# Altium schematic sheet 10 Digital IO_5V.SchDoc
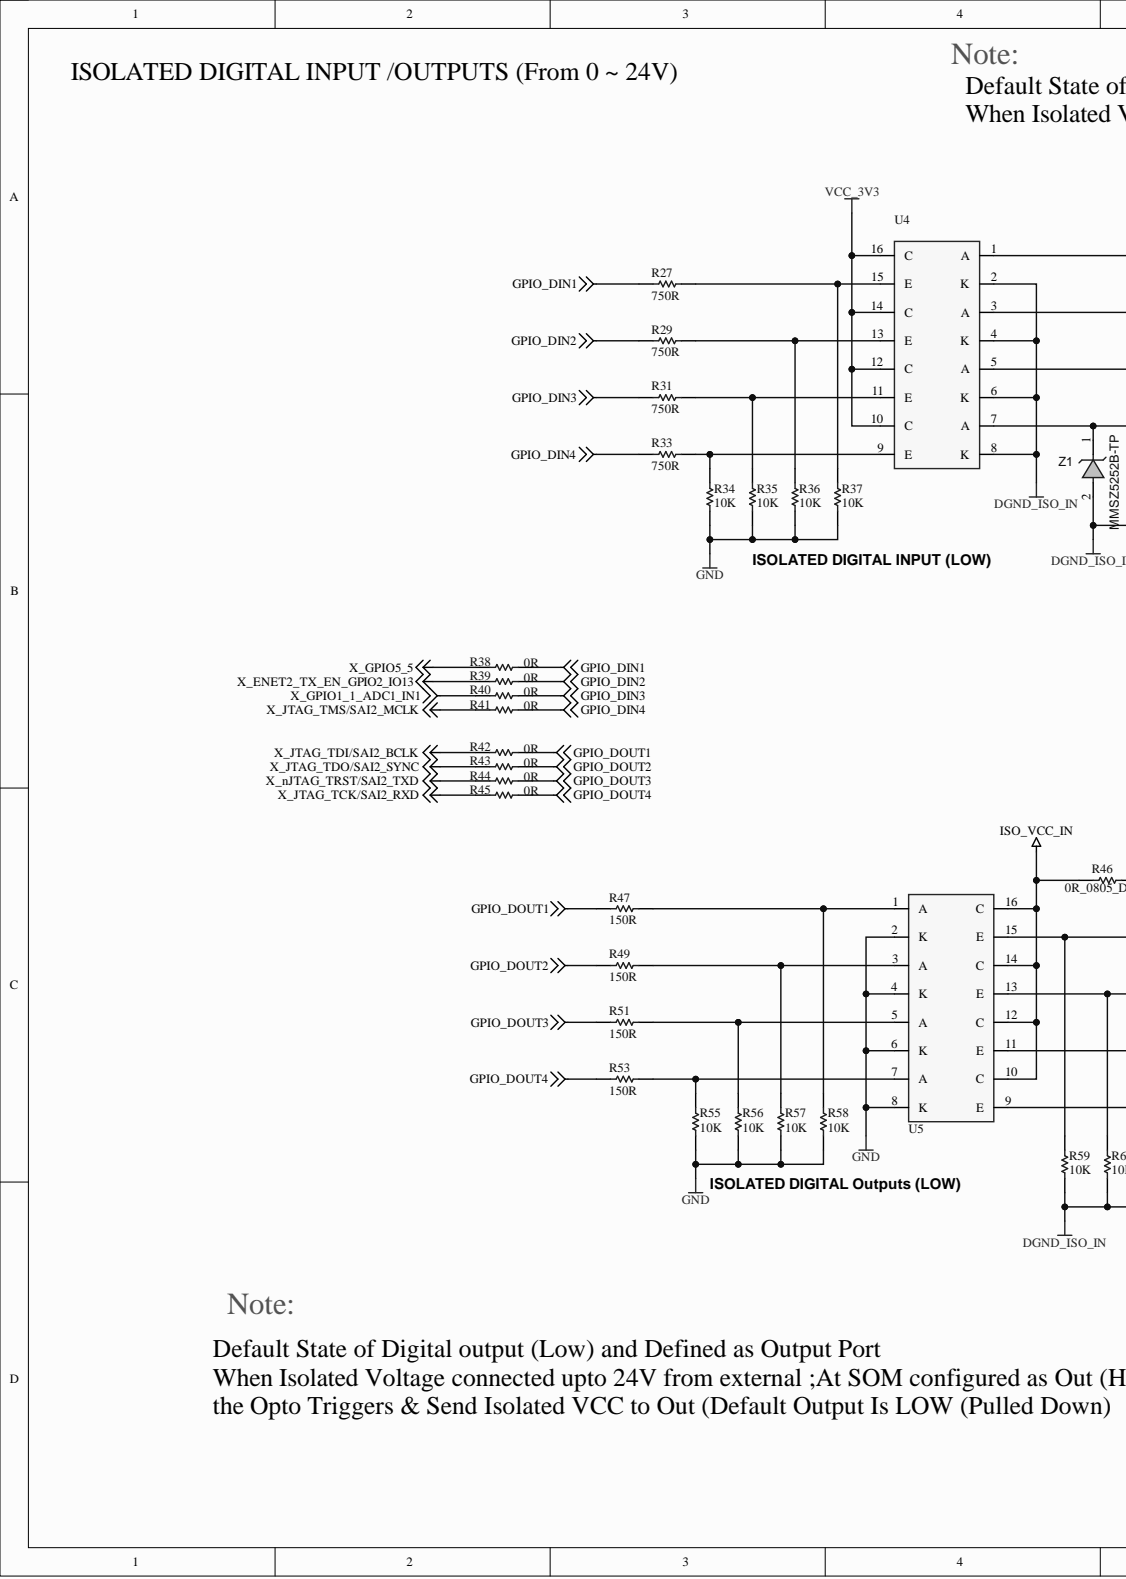
[diagram p0: 10 Digital IO_5V.SchDoc, left]
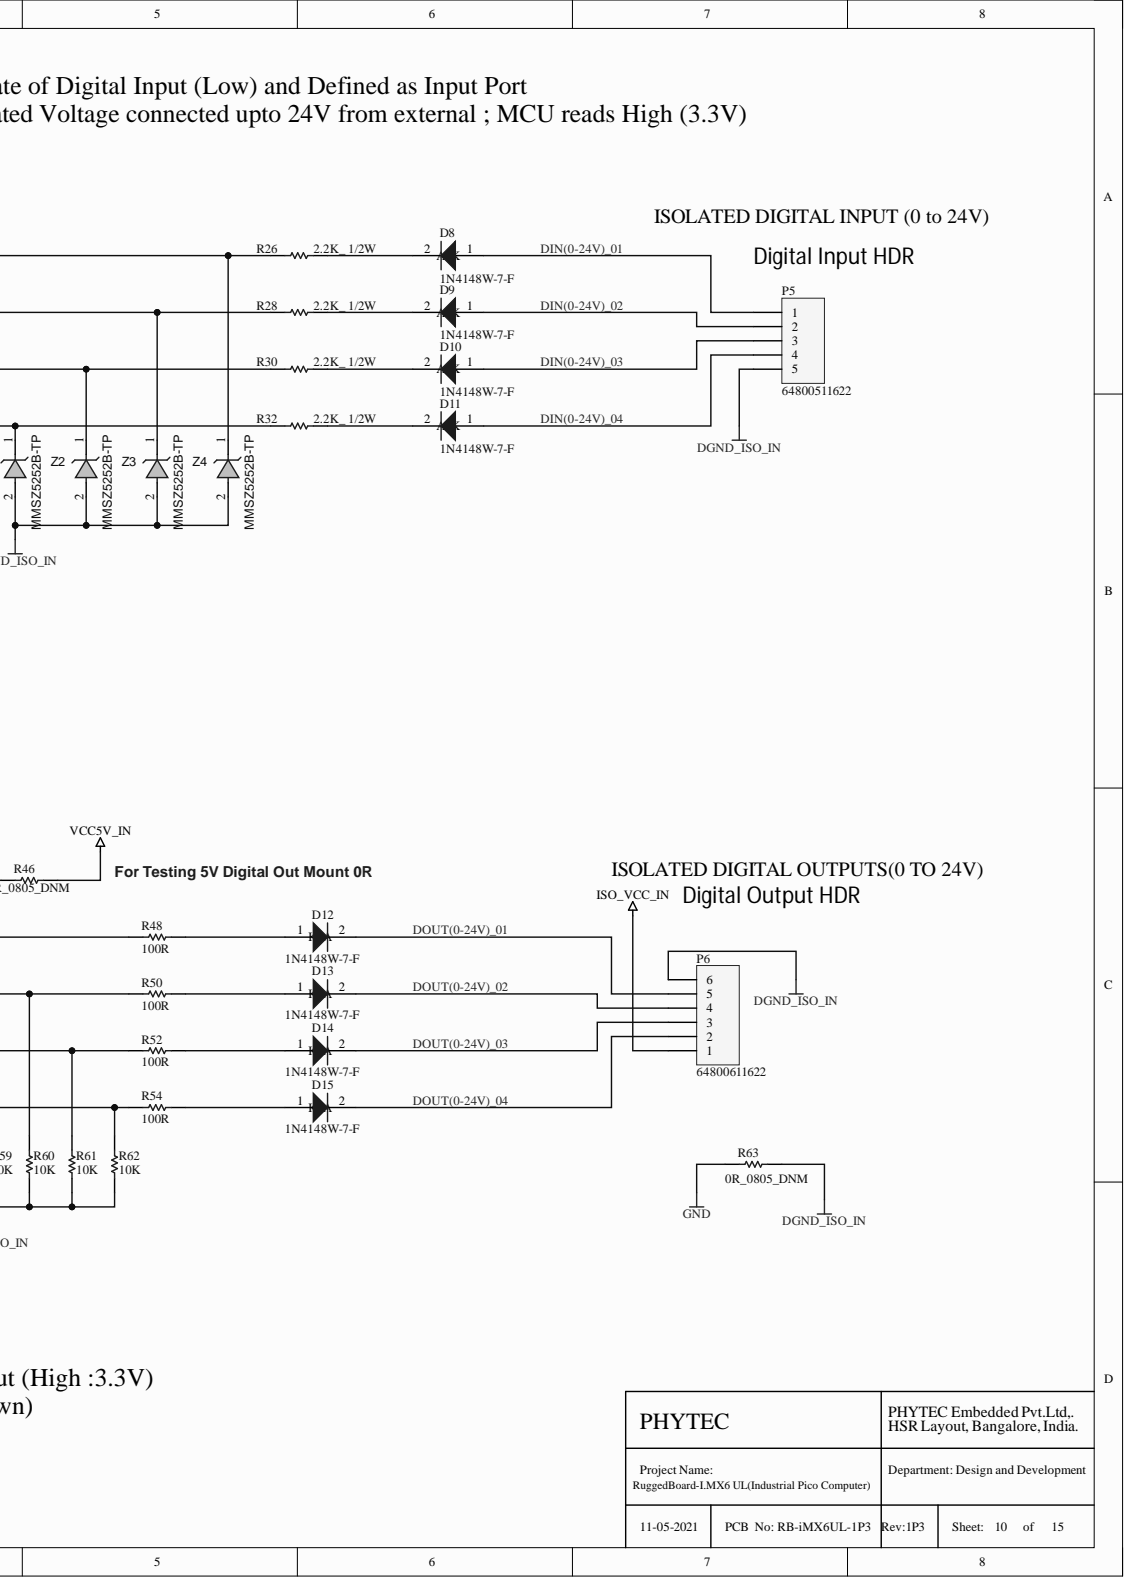
[diagram p1: 10 Digital IO_5V.SchDoc, right]

## Parts
D8  1N4148W-7-F  [SOD-123_1A_2K]
D9  1N4148W-7-F  [SOD-123_1A_2K]
D10  1N4148W-7-F  [SOD-123_1A_2K]
D11  1N4148W-7-F  [SOD-123_1A_2K]
D12  1N4148W-7-F  [SOD-123_1A_2K]
D13  1N4148W-7-F  [SOD-123_1A_2K]
D14  1N4148W-7-F  [SOD-123_1A_2K]
D15  1N4148W-7-F  [SOD-123_1A_2K]
P5  64800511622  [HEADER_15P_1X5N]
P6  64800611622  [HEADER_15P_1X6N]
R26  2.2K_ 1/2W  [R0805]
R27  750R  [R0402]
R28  2.2K_ 1/2W  [R0805]
R29  750R  [R0402]
R30  2.2K_ 1/2W  [R0805]
R31  750R  [R0402]
R32  2.2K_ 1/2W  [R0805]
R33  750R  [R0402]
R34  10K  [R0402]
R35  10K  [R0402]
R36  10K  [R0402]
R37  10K  [R0402]
R38  0R  [R0402]
R39  0R  [R0402]
R40  0R  [R0402]
R41  0R  [R0402]
R42  0R  [R0402]
R43  0R  [R0402]
R44  0R  [R0402]
R45  0R  [R0402]
R46  0R_0805_DNM  [R0805]
R47  150R  [R0402]
R48  100R  [R0402]
R49  150R  [R0402]
R50  100R  [R0402]
R51  150R  [R0402]
R52  100R  [R0402]
R53  150R  [R0402]
R54  100R  [R0402]
R55  10K  [R0402]
R56  10K  [R0402]
R57  10K  [R0402]
R58  10K  [R0402]
R59  10K  [R0402]
R60  10K  [R0402]
R61  10K  [R0402]
R62  10K  [R0402]
R63  0R_0805_DNM  [R0805]
U4  TLP293-4(MBGBTPE  [SOIC127P405X179-16N]  (value not drawn)
U5  TLP293-4(MBGBTPE  [SOIC127P405X179-16N]  (value not drawn)
Z1  MMSZ5252B-TP  [SOD-123]
Z2  MMSZ5252B-TP  [SOD-123]
Z3  MMSZ5252B-TP  [SOD-123]
Z4  MMSZ5252B-TP  [SOD-123]

## Nets
DGND_ISO_IN: P5.5 P6.6 R59.1 R60.1 R61.1 R62.1 R63.1 U4.2(K) U4.4(K) U4.6(K) U4.8(K) Z1.2 Z2.2 Z3.2 Z4.2
GND: R34.1 R35.1 R36.1 R37.1 R55.1 R56.1 R57.1 R58.1 R63.2 U5.2(K) U5.4(K) U5.6(K) U5.8(K)
GPIO_DIN1: R27.2 R38.1
GPIO_DIN2: R29.2 R39.1
GPIO_DIN3: R31.2 R40.1
GPIO_DIN4: R33.2 R41.1
GPIO_DOUT1: R42.1 R47.2
GPIO_DOUT2: R43.1 R49.2
GPIO_DOUT3: R44.1 R51.2
GPIO_DOUT4: R45.1 R53.2
ISO_VCC_IN: P6.1 R46.2 U5.10(C) U5.12(C) U5.14(C) U5.16(C)
VCC5V_IN: R46.1
VCC_3V3: U4.10(C) U4.12(C) U4.14(C) U4.16(C)
X_ENET2_TX_EN_GPIO2_IO13: R39.2
X_GPIO1_1_ADC1_IN1: R40.2
X_GPIO5_5: R38.2
X_JTAG_TCK/SAI2_RXD: R45.2
X_JTAG_TDI/SAI2_BCLK: R42.2
X_JTAG_TDO/SAI2_SYNC: R43.2
X_JTAG_TMS/SAI2_MCLK: R41.2
X_nJTAG_TRST/SAI2_TXD: R44.2
DIN(0-24V)_01: D8.1 P5.1
DIN(0-24V)_02: D9.1 P5.2
DIN(0-24V)_03: D10.1 P5.3
DIN(0-24V)_04: D11.1 P5.4
DOUT(0-24V)_01: D12.2 P6.5
DOUT(0-24V)_02: D13.2 P6.4
DOUT(0-24V)_03: D14.2 P6.3
DOUT(0-24V)_04: D15.2 P6.2
NetD8_2: D8.2 R26.1
NetD9_2: D9.2 R28.1
NetD10_2: D10.2 R30.1
NetD11_2: D11.2 R32.1
NetD12_1: D12.1 R48.1
NetD13_1: D13.1 R50.1
NetD14_1: D14.1 R52.1
NetD15_1: D15.1 R54.1
NetR26_2: R26.2 U4.1(A) Z4.1
NetR27_1: R27.1 R37.2 U4.15(E)
NetR28_2: R28.2 U4.3(A) Z3.1
NetR29_1: R29.1 R36.2 U4.13(E)
NetR30_2: R30.2 U4.5(A) Z2.1
NetR31_1: R31.1 R35.2 U4.11(E)
NetR32_2: R32.2 U4.7(A) Z1.1
NetR33_1: R33.1 R34.2 U4.9(E)
NetR47_1: R47.1 R58.2 U5.1(A)
NetR48_2: R48.2 R59.2 U5.15(E)
NetR49_1: R49.1 R57.2 U5.3(A)
NetR50_2: R50.2 R60.2 U5.13(E)
NetR51_1: R51.1 R56.2 U5.5(A)
NetR52_2: R52.2 R61.2 U5.11(E)
NetR53_1: R53.1 R55.2 U5.7(A)
NetR54_2: R54.2 R62.2 U5.9(E)

## Library data of the parts
D8-D15 = Diode: DIODE GEN PURP 100V 300MA SOD123; ASSEMBLY_TYPE: SMD; MFG: Diodes Incorporated; Component Kind: Standard; Library Name: Miscellaneous Devices.IntLib; MFG_PN: 1N4148W-7-F; PACKAGE: SOD123
P5 = Header 5: CONN HEADER ZH TOP 5POS 1.5MM; MFG: WE; Component Kind: Standard; Library Name: Miscellaneous Connectors.IntLib; MFG_PN: 64800511622
P6 = Header 6: CONN HEADER VERT 6POS 1.5MM; MFG: JST Sales America Inc.; Component Kind: Standard; Library Name: Miscellaneous Connectors.IntLib; MFG_PN: 64800611622; PACKAGE: TH
R26 R28 R30 R32 = Res1: RES SMD 2.2K OHM 5% 1/2W 0805; MFG: PEC; MFG_PN: ERJ-P06J222V; Component Kind: Standard; Library Name: Miscellaneous Devices.IntLib; PACKAGE: 0805; PACKAGE_TYPE: SMT
R27 R29 R31 R33 = Res1: RES SMD 750 OHM 1% 1/16W 0402; MFG: YAGEO; MFG_PN: RC0402FR-07750RL; Component Kind: Standard; Library Name: Miscellaneous Devices.IntLib; PACKAGE: 0402; PACKAGE_TYPE: SMT; Signal Integrity: Res; Simulation: RESISTOR
R34-R37 R55-R62 = Res1: RES SMD 10K OHM 1% 1/16W 0402; MFG: YAGEO; MFG_PN: RC0402FR-0710KL; Component Kind: Standard; Library Name: Miscellaneous Devices.IntLib; PACKAGE: 0402; PACKAGE_TYPE: SMT; Signal Integrity: Res; Simulation: RESISTOR
R38-R45 = Res1: RES SMD 0.0OHM JUMPER 1/16W 0402; ASSEMBLY_TYPE: SMT; MFG: YAGE0; MFG_PN: RC0402JR-070RL; Component Kind: Standard; Library Name: Miscellaneous Devices.IntLib; PACKAGE: 0402; Signal Integrity: Res; Simulation: RESISTOR
R46 R63 = Res1: RES SMD 0.0OHM JUMPER 1/8W 0805; ASSEMBLY_TYPE: SMT; MFG: Yageo; MFG_PN: AC0805JR-070RL; Component Kind: Standard; Library Name: Miscellaneous Devices.IntLib; PACKAGE: 0805
R47 R49 R51 R53 = Res1: RES SMD 150 OHM 1% 1/16W 0402; MFG: YAGEO; MFG_PN: RC0402FR-07150RL; Component Kind: Standard; Library Name: Miscellaneous Devices.IntLib; PACKAGE: 0402; PACKAGE_TYPE: SMT; Signal Integrity: Res; Simulation: RESISTOR
R48 R50 R52 R54 = Res1: RES SMD 100 OHM 1% 1/16W 0402; MFG: YAGEO; MFG_PN: RC0402FR-07100RL; Component Kind: Standard; Library Name: Miscellaneous Devices.IntLib; PACKAGE: 0402; PACKAGE_TYPE: SMT; Signal Integrity: Res; Simulation: RESISTOR
U4 U5 = IC_TLP-281-4: OPTOISOLATOR 3.75KV TRANS SO16; MFG: Toshiba; Component Kind: Standard; MFG_PN: TLP293-4(MBGBTPE; Mounting Type: Surface mount; Operating Temperature: -55 Deg C to +100 Deg C; Package: SOIC-16; RoHS: Yes; Library Name: Datalog_WEGA_Rev A0.SCHLIB
Z1-Z4 = Diode_Zener_MMSZ4680T1G_: DIODE ZENER 24V 500MW SOD123; ASSEMBLY_TYPE: Surface mount; MFG: Micro Commercial Co; Component Kind: Standard; MFG_PN: MMSZ5252B-TP; PACKAGE: SOD123; Library Name: Phytec_Schlib.SchLib
